# Altium schematic sheet Sheet2.SchDoc
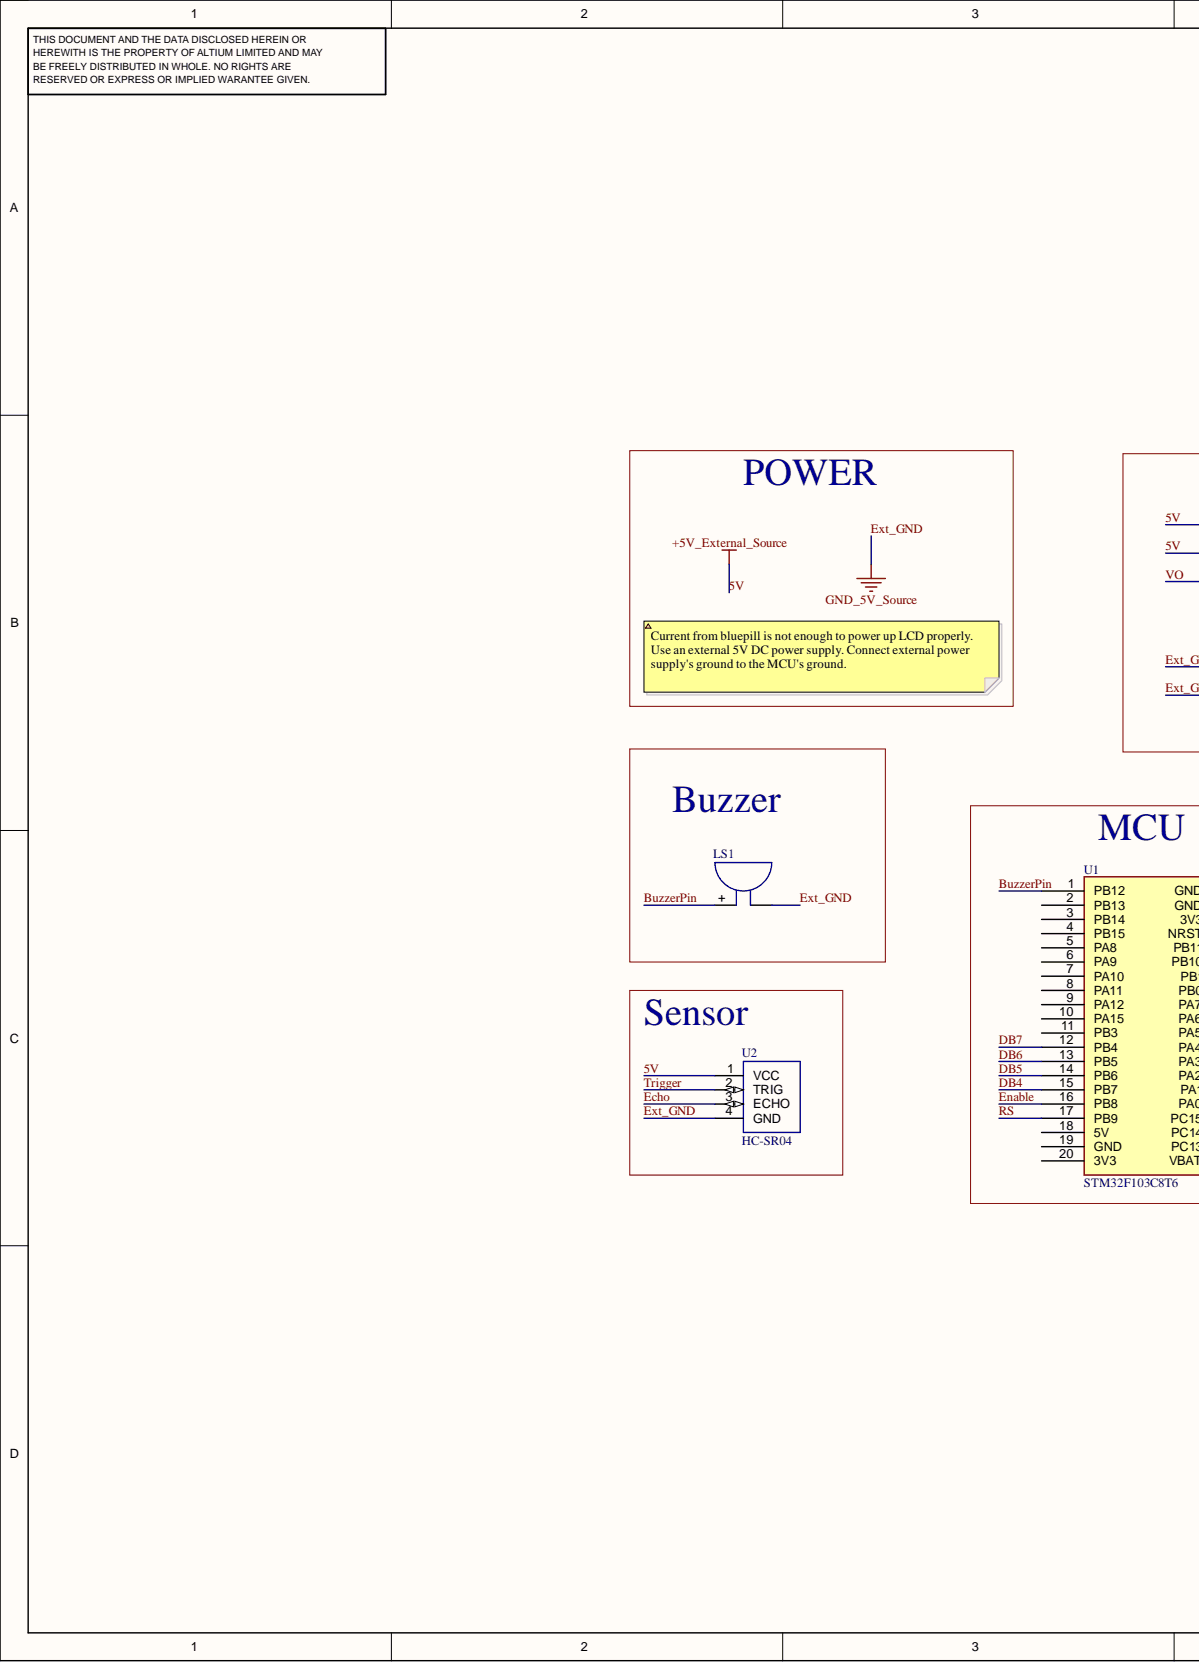
[diagram p0: Sheet2.SchDoc, left]
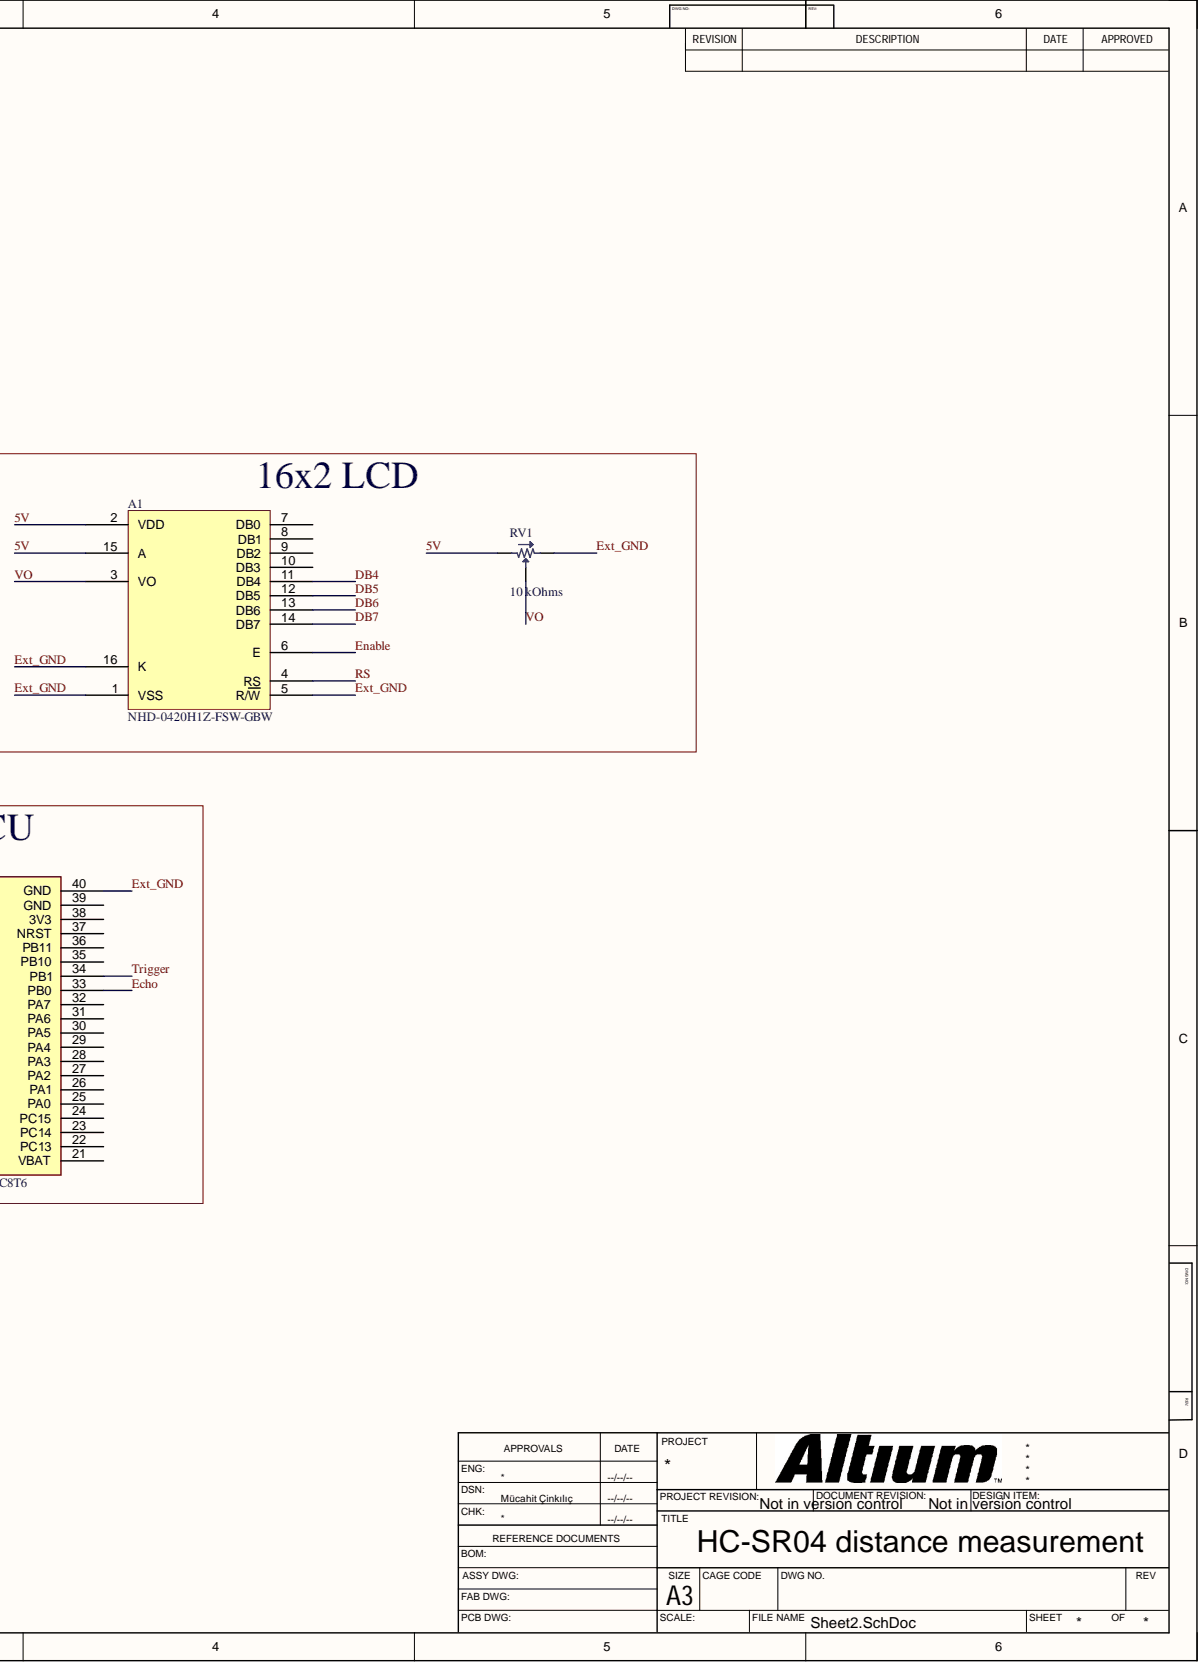
[diagram p1: Sheet2.SchDoc, right]

## Parts
A1  NHD-0420H1Z-FSW-GBW  [NEWHAVEN DISPLAY NHD-0420H1Z-FSW-GBW]
LS1  CT-1205H-SMT-TR  [CUI CT-1205H-SMT-TR]  (value not drawn)
RV1  10 kOhms  [POT BOURNS TRIMPOT TC33]
U1  STM32F103C8T6  [Stm32 BluePill]
U2  HC-SR04  [XCVR_HC-SR04]

## Nets
5V: A1.2(VDD) A1.15(A) RV1.1 U2.1(VCC)
BuzzerPin: LS1.+ U1.1(PB12)
DB4: A1.11(DB4) U1.15(PB7)
DB5: A1.12(DB5) U1.14(PB6)
DB6: A1.13(DB6) U1.13(PB5)
DB7: A1.14(DB7) U1.12(PB4)
Echo: U1.33(PB0) U2.3(ECHO)
Enable: A1.6(E) U1.16(PB8)
Ext_GND: A1.1(VSS) A1.5(R/W) A1.16(K) LS1.- RV1.3 U1.40(GND) U2.4(GND)
RS: A1.4(RS) U1.17(PB9)
Trigger: U1.34(PB1) U2.2(TRIG)
VO: A1.3(VO) RV1.2

Not connected: A1 7(DB0) 8(DB1) 9(DB2) 10(DB3); U1 2(PB13) 3(PB14) 4(PB15) 5(PA8) 6(PA9) 7(PA10) 8(PA11) 9(PA12) 10(PA15) 11(PB3) 18(5V) 19(GND) 20(3V3) 21(VBAT) 22(PC13) 23(PC14) 24(PC15) 25(PA0) 26(PA1) 27(PA2) 28(PA3) 29(PA4) 30(PA5) 31(PA6) 32(PA7) 35(PB10) 36(PB11) 37(NRST) 38(3V3) 39(GND)

## Library data of the parts
A1 = NHD-0420H1Z-FSW-GBW: LCD MOD 80DIG 20X4 TRANSFLCT WHT; Manufacturer: Newhaven Display Intl; Background Color: White; Backlight: LED - White; Character Format: 5 x 8 Dots; Character Size: 5.15mm H x 2.35mm W; Database Table Name: Display Modules - LCD OLED Character and Numeric; Display Mode: Transflective; Display Type: STN - Super-Twisted Nematic; Dot Size: 0.43mm W x 0.60mm H; Number of Characters: 80; Outline L x W x H: 79.00mm x 36.00mm x 13.00mm; Text Color: Gray; Viewing Area: 60.00mm L x 28.00mm W; Voltage - Supply: 4.7V ~ 5.5V; Interface: Parallel; Component Kind: Standard; ComponentLink1Description: Datasheet; ComponentLink1URL: http://www.newhavendisplay.com/specs/NHD-0420H1Z-FSW-GBW.pdf; ComponentLink2Description: DigiKey Link; ComponentLink2URL: https://www.digikey.com/en/products/detail/newhaven-display-intl/NHD-0420H1Z-FSW-GBW/4036532; Display Format: 20 x 4; LastUpdated: 13.08.2022 20:54:19; Library Name: Celestial Altium Library - altium_library.DbLib; Lifecycle Status: Active; Minimum Order: 1; Operating Temperature: -20°C ~ 70°C; Packaging: Box; Part Number: NHD-0420H1Z-FSW-GBW; Part Status: Active; PartId: 9; Price: 17.97; Series: -; Supplier 1: DigiKey; Supplier Part Number 1: NHD-0420H1Z-FSW-GBW-ND
LS1 = CT-1205H-SMT-TR: AUDIO MAGNETIC XDCR 3-7V SMD; Manufacturer: CUI Devices; Current - Supply: 60mA; Database Table Name: Audio - Alarms Buzzers and Sirens; Driver Circuitry: Transducer, Externally Driven; Frequency: 2.4kHz; Operating Mode: Single Tone; Port Location: Top; Ratings: Washable; Sound Pressure Level (SPL): 88dB @ 5V, 10cm; Voltage - Rated: 5V; Voltage Range: 3 ~ 7V; Input Type: Zero-Peak Signal; Component Kind: Standard; ComponentLink1Description: Datasheet; ComponentLink1URL: https://www.cuidevices.com/product/resource/digikeypdf/ct-1205h-smt-tr.pdf; ComponentLink2Description: DigiKey Link; ComponentLink2URL: http://digikey.com/product-detail/en/cui-devices/CT-1205H-SMT-TR/102-1266-1-ND/610974; Height - Seated (Max): 0.406" (10.30mm); LastUpdated: 24.04.2020 00:37:20; Library Name: Celestial Altium Library - altium_library.DbLib; Lifecycle Status: Active; Minimum Order: 1; Mounting Type: Surface Mount; Operating Temperature: -30°C ~ 70°C; Packaging: TapeAndReel; Part Number: CT-1205H-SMT-TR; Part Status: Active; Price: 0.95; Series: CT; Size / Dimension: 0.504" L x 0.504" W (12.80mm x 12.80mm); Supplier 1: DigiKey; Supplier Part Number 1: 102-1266-1-ND; Technology: Magnetic; Termination: Solder Pads
RV1 = TC33X-2-103E: TRIMMER 10K OHM 0.15W J LEAD TOP; Manufacturer: Bourns Inc.; Adjustment Type: Top Adjustment; Database Table Name: Resistors - Trimmer Potentiometers; Number of Turns: 1; Resistive Material: Cermet; Temperature Coefficient: ±250ppm/°C; Tolerance: ±25%; Component Kind: Standard; ComponentLink1Description: Datasheet; ComponentLink1URL: https://www.bourns.com/docs/Product-Datasheets/TC33.pdf; ComponentLink2Description: DigiKey Link; ComponentLink2URL: https://www.digikey.com/en/products/detail/bourns-inc/TC33X-2-103E/612858; LastUpdated: 3.06.2024 20:01:52; Library Name: Celestial Altium Library - altium_library.DbLib; Lifecycle Status: Active; Minimum Order: 1; Mounting Type: Surface Mount; Packaging: TapeAndReel; Part Number: TC33X-2-103E; Part Status: Active; PartId: 8; Power (Watts): 0.15W; Price: 0.11; Resistance: 10 kOhms; Series: Trimpot® TC33 - Open Frame; Size / Dimension: Rectangular - 0.150" x 0.142" Face (3.80mm x 3.60mm); Supplier 1: DigiKey; Supplier Part Number 1: TC33X-103ECT-ND; Termination Style: J Lead; Value: 10 kOhms
U1 = BluePill: Designed By @Alixahedi; Component Kind: Standard; Library Name: MyAltLib.SchLib
U2 = HC-SR04: Ultrasonic Sensor Module; Availability: Unavailable; Component Kind: Standard; Library Name: MyAltLib.SchLib; MP: HC-SR04; Package: None; Price: None
